# Altium schematic sheet PS_Ethernet.SchDoc
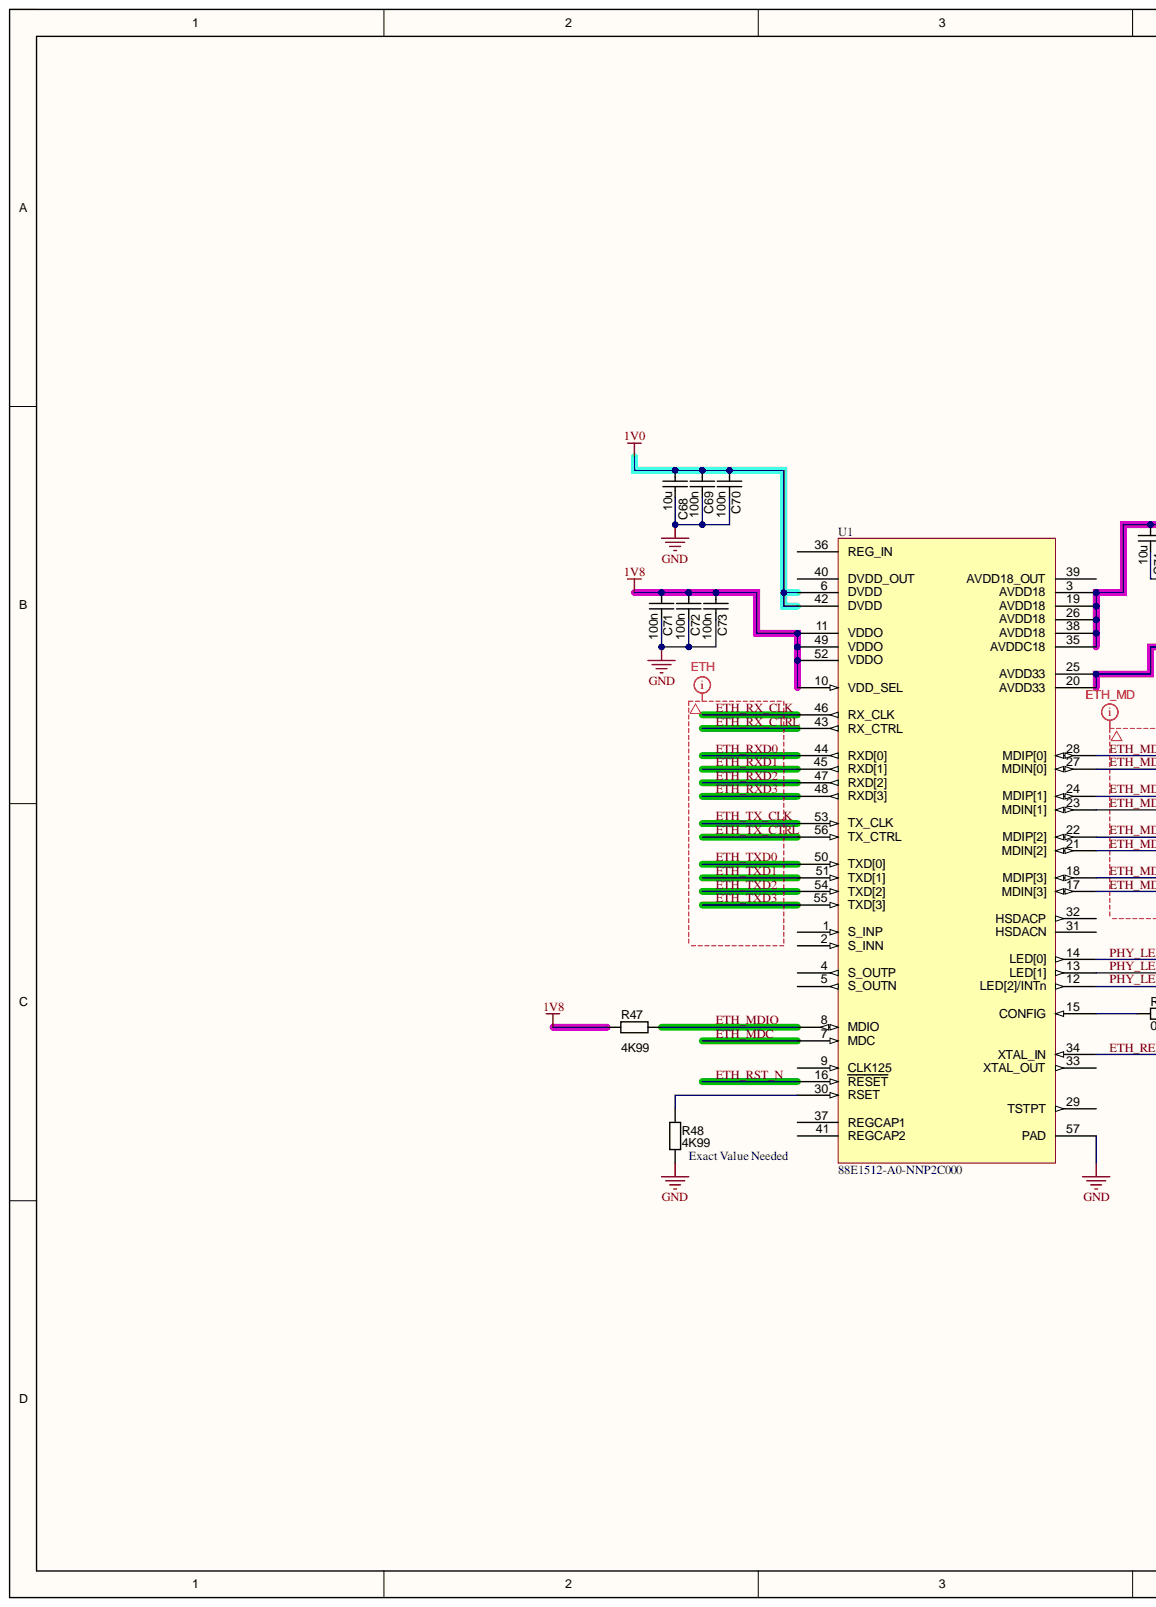
[diagram p0: PS_Ethernet.SchDoc, left]
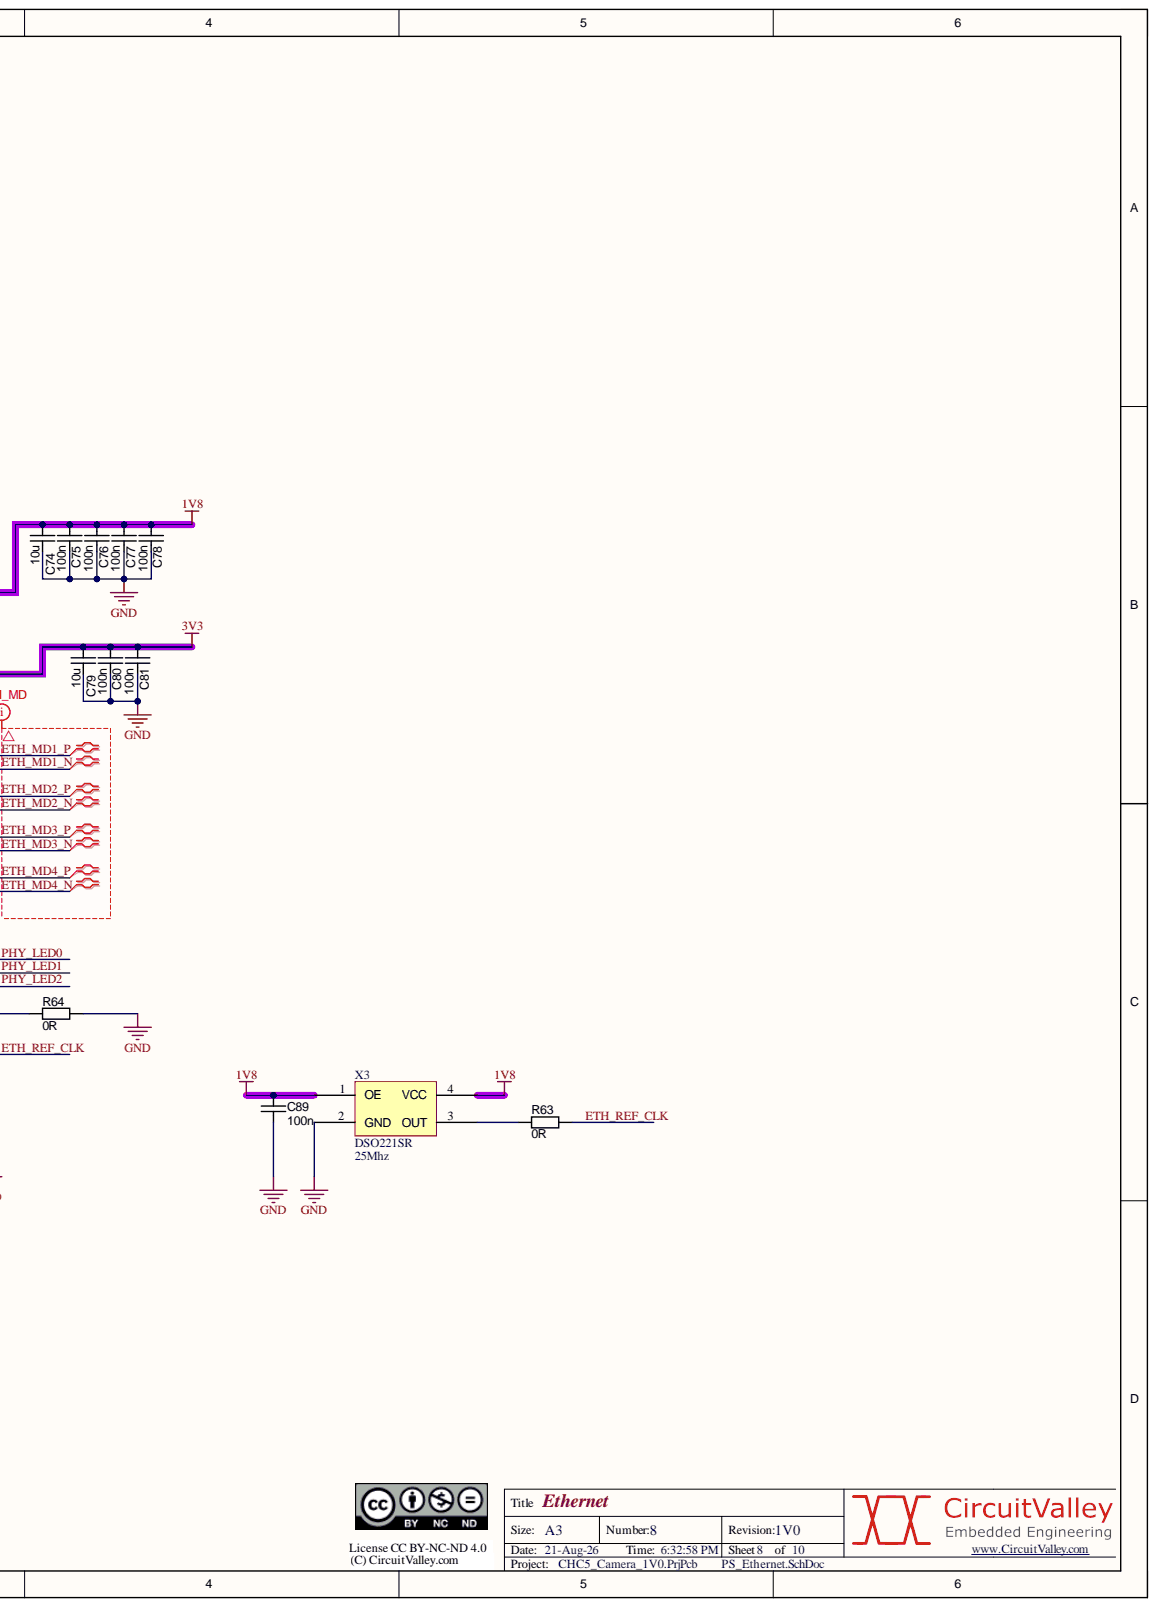
[diagram p1: PS_Ethernet.SchDoc, right]

## Parts
C68  value 10u  [CAPC1608N]  (hidden comment: approved sub OK)
C69  100n  [CAPC1005N]
C70  100n  [CAPC1005N]
C71  100n  [CAPC1005N]
C72  100n  [CAPC1005N]
C73  100n  [CAPC1005N]
C74  value 10u  [CAPC1608N]  (hidden comment: approved sub OK)
C75  100n  [CAPC1005N]
C76  100n  [CAPC1005N]
C77  100n  [CAPC1005N]
C78  100n  [CAPC1005N]
C79  value 10u  [CAPC1608N]  (hidden comment: approved sub OK)
C80  100n  [CAPC1005N]
C81  100n  [CAPC1005N]
C89  100n  [CAPC1005N]
R47  value 4K99  [RESC1005N]  (hidden comment: Thick Film)
R48  value 4K99  [RESC1005N]  (hidden comment: Thick Film)
R63  value 0R  [RESC1005N]  (hidden comment: Thick Film)
R64  value 0R  [RESC1005N]  (hidden comment: Thick Film)
U1  88E1512-A0-NNP2C000  [QFN56_8x8]
X3  DSO221SR  value 25Mhz  [Active Oscillator 2520]

## Nets
1V0: C68.1 C69.2 C70.2 U1.6(DVDD) U1.42(DVDD)
1V8: C71.2 C72.2 C73.2 C74.1 C75.2 C76.2 C77.2 C78.2 C89.2 R47.1 U1.3(AVDD18) U1.10(VDD_SEL) U1.11(VDDO) U1.19(AVDD18) U1.26(AVDD18) U1.35(AVDDC18) U1.38(AVDD18) U1.49(VDDO) U1.52(VDDO) X3.1(OE) X3.4(VCC)
3V3: C79.1 C80.2 C81.2 U1.20(AVDD33) U1.25(AVDD33)
GND: C68.2 C69.1 C70.1 C71.1 C72.1 C73.1 C74.2 C75.1 C76.1 C77.1 C78.1 C79.2 C80.1 C81.1 C89.1 R48.1 R64.2 U1.57(PAD) X3.2(GND)
ETH_MD1_N: U1.27(MDIN[0])
ETH_MD1_P: U1.28(MDIP[0])
ETH_MD2_N: U1.23(MDIN[1])
ETH_MD2_P: U1.24(MDIP[1])
ETH_MD3_N: U1.21(MDIN[2])
ETH_MD3_P: U1.22(MDIP[2])
ETH_MD4_N: U1.17(MDIN[3])
ETH_MD4_P: U1.18(MDIP[3])
ETH_MDC: U1.7(MDC)
ETH_MDIO: R47.2 U1.8(MDIO)
ETH_REF_CLK: R63.2 U1.34(XTAL_IN)
ETH_RST_N: U1.16(RESET)
ETH_RX_CLK: U1.46(RX_CLK)
ETH_RX_CTRL: U1.43(RX_CTRL)
ETH_RXD0: U1.44(RXD[0])
ETH_RXD1: U1.45(RXD[1])
ETH_RXD2: U1.47(RXD[2])
ETH_RXD3: U1.48(RXD[3])
ETH_TX_CLK: U1.53(TX_CLK)
ETH_TX_CTRL: U1.56(TX_CTRL)
ETH_TXD0: U1.50(TXD[0])
ETH_TXD1: U1.51(TXD[1])
ETH_TXD2: U1.54(TXD[2])
ETH_TXD3: U1.55(TXD[3])
PHY_LED0: U1.14(LED[0])
PHY_LED1: U1.13(LED[1])
PHY_LED2: U1.12(LED[2]/INTn)
NetR48_2: R48.2 U1.30(RSET)
NetR63_1: R63.1 X3.3(OUT)
NetR64_1: R64.1 U1.15(CONFIG)

Not connected: U1 1(S_INP) 2(S_INN) 4(S_OUTP) 5(S_OUTN) 9(CLK125) 29(TSTPT) 31(HSDACN) 32(HSDACP) 33(XTAL_OUT) 36(REG_IN) 37(REGCAP1) 39(AVDD18_OUT) 40(DVDD_OUT) 41(REGCAP2)

## Library data of the parts
C68 C74 C79 = CAPC_560p_0603_5%: Capacitor SMD Cer 560pF 5% 50V NP0 0603; Manufacturer 1: AVX Corporation; Manufacturer Part Number 1: 06035A561JAT2A; Capacitance: 470pF; Material: ceramic; Ratings: 50V; NP0; Applications: General Purpose; Component Kind: Standard; ComponentLink1Description: http://www.avx.com/docs/Catalogs/ccog.pdf; ComponentLink1URL: http://www.avx.com/docs/Catalogs/ccog.pdf; Height - Seated (Max): -; Lead Spacing: -; Lead Style: -; Library Name: PBL - Capacitors.SCHLIB; Mounting Type: Surface Mount, MLCC; Operating Temperature: -55°C ~ 125°C; Package / Case: 0603 (1608 metric); Size / Dimension: 0.063" L x 0.032" W (1.60mm x 0.81mm); Supplier 1: Digi-Key; Supplier Part Number 1: 478-1184-1-ND; Thickness (Max): 0.035" (0.90mm); Voltage - Rated: 50V
C69-C73 C75-C78 C80 C81 C89 = CAPC_10n_0402_16V_10%: Capacitor SMD Cer 10nF 10% 16V X7R 0402; Manufacturer 1: AVX Corporation; Manufacturer Part Number 1: 0402YC103KAT2A; Ratings: 16V; X7R; Component Kind: Standard; ComponentLink1Description: http://www.avx.com/docs/Catalogs/cx7r.pdf; ComponentLink1URL: http://www.avx.com/docs/Catalogs/cx7r.pdf; ComponentLink2Description: http://www.yageo.com/documents/recent/UPY-GPHC_X7R_6.3V-to-50V_8.pdf; ComponentLink2URL: http://www.yageo.com/documents/recent/UPY-GPHC_X7R_6.3V-to-50V_8.pdf; Library Name: PBL - Capacitors.SCHLIB; Manufacturer 2: Yageo; Manufacturer Part Number 2: CC0402KRX7R7BB103; Operating Temperature: -55°C ~ 125°C; Package / Case: 0402 (1005 Metric); Size / Dimension: 0.039" L x 0.020" W (1.00mm x 0.50mm); Supplier 1: Digi-Key; Supplier 2: Digi-Key; Supplier Part Number 1: 478-1114-1-ND; Supplier Part Number 2: 311-1042-1-ND; Tolerance: ±10%; Value: 100n
R47 R48 R63 R64 = RES_3K3_0402_5%: Resistor SMD chip 3.3k Ohm 0.063W 5% 0402; Manufacturer 1: Yageo; Manufacturer Part Number 1: RC0402JR-073K3L; Ratings: 1/16 W; Component Kind: Standard; ComponentLink1Description: http://www.yageo.com/documents/recent/PYu-RC0402_51_RoHS_L_6.pdf; ComponentLink1URL: http://www.yageo.com/documents/recent/PYu-RC0402_51_RoHS_L_6.pdf; Library Name: PBL - Resistors.SCHLIB; Material: Thick Film; Package / Case: 0402 (1005 metric); Supplier 1: Digi-Key; Supplier Part Number 1: 311-3.3KJRCT-ND; Tolerance: ±5%
U1 = 88E1512-A0-NNP2: Component Kind: Standard; Library Name: cvlib.SchLib
X3 = Oscillator_2520: Active Oscillator_2520; Component Kind: Standard; Library Name: Crystal_and_oscillator.SchLib
